# Altium schematic sheet Connectors.SchDoc(Connectors)
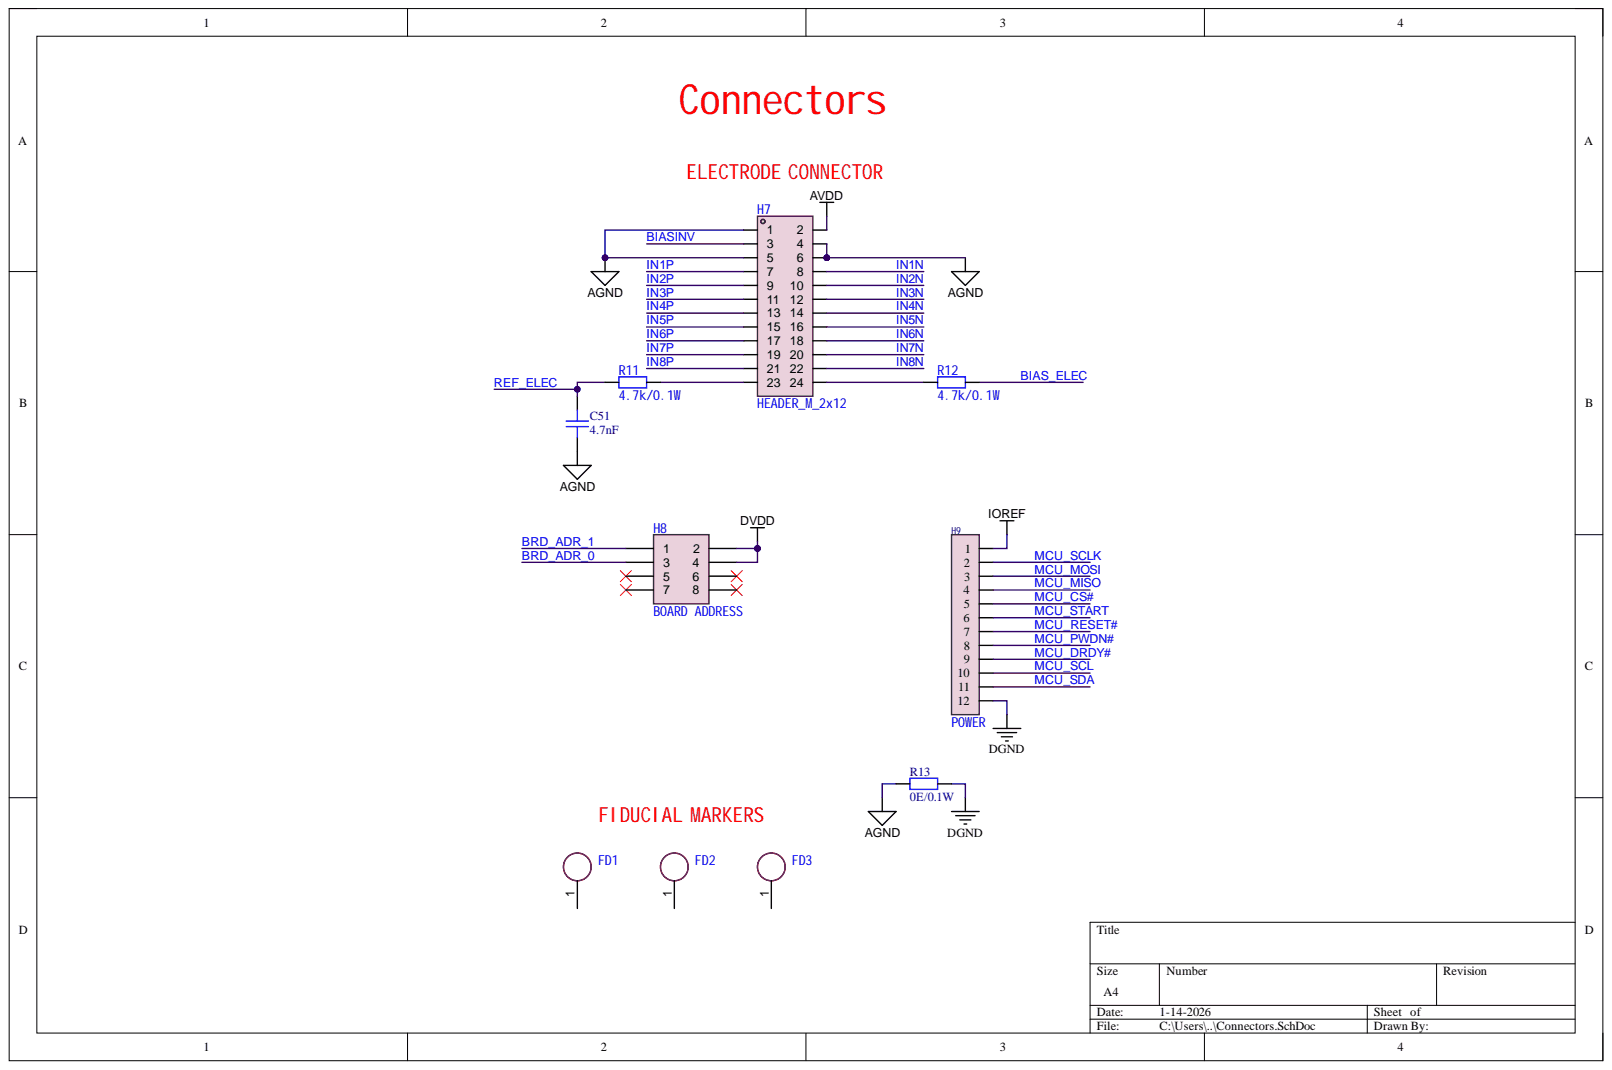
[diagram p0: Connectors.SchDoc]

## Parts
C51  value 4.7nF  [c0603]  (hidden comment: C0603C472K4RECAUTO)
FD1  FIDUCIAL MARKER  [FIDUCIAL MARKER]
FD2  FIDUCIAL MARKER  [FIDUCIAL MARKER]
FD3  FIDUCIAL MARKER  [FIDUCIAL MARKER]
H7  HEADER_M_2x12  [HEADER_M_2x12]
H8  BOARD ADDRESS  [HDR-TH_8P-P2.54-V-F-R2-C4-S2.54-1]
H9  POWER  [HDR-TH_12P-P2.54-V-F]
R11  4.7k  value 4.7k/0.1W  [R0603]
R12  4.7k  value 4.7k/0.1W  [R0603]
R13  value 0E/0.1W  [r0603]  (hidden comment: RC0603JR-070RL)

## Nets
AGND: C51.2 H7.1 H7.4 H7.5 H7.6 R13.1
AVDD: H7.2
DGND: H9.12 R13.2
DVDD: H8.2 H8.4
IOREF: H9.1
BIAS_ELEC: R12.2
BIASINV: H7.3
BRD_ADR_0: H8.3
BRD_ADR_1: H8.1
IN1N: H7.8
IN1P: H7.7
IN2N: H7.10
IN2P: H7.9
IN3N: H7.12
IN3P: H7.11
IN4N: H7.14
IN4P: H7.13
IN5N: H7.16
IN5P: H7.15
IN6N: H7.18
IN6P: H7.17
IN7N: H7.20
IN7P: H7.19
IN8N: H7.22
IN8P: H7.21
MCU_CS#: H9.5
MCU_DRDY#: H9.9
MCU_MISO: H9.4
MCU_MOSI: H9.3
MCU_PWDN#: H9.8
MCU_RESET#: H9.7
MCU_SCL: H9.10
MCU_SCLK: H9.2
MCU_SDA: H9.11
MCU_START: H9.6
REF_ELEC: C51.1 R11.1
NetH7_23: H7.23 R11.2
NetH7_24: H7.24 R12.1

Not connected: FD1 1; FD2 1; FD3 1; H8 5 6 7 8

## Library data of the parts
C51 = C0603C472K4RECAUTO: CAP CER 0603 4.7NF 16V X7R 10%; Component Kind: Standard; ComponentLink1Description: Product Link; ComponentLink1URL: https://www.digikey.in/en/products/detail/kemet/C0603C472K4RECAUTO/8640473; ComponentLink2Description: Datasheet Link; ComponentLink2URL: https://www.yageogroup.com/content/datasheet/asset/file/KEM_C1090_X7R_ESD; Library Name: US00001.SCHLIB
FD1-FD3 = FIDUCIAL MARKER: Add into BOM: yes; Component Kind: Standard; Convert to PCB: yes; Device: FIDUCIAL MARKER; Library Name: US00001.SCHLIB; Symbol: FIDUCIAL MARKER
H7 = HEADER_M_2x12: Add into BOM: yes; Component Kind: Standard; Convert to PCB: yes; Device: HEADER_M_2x12; Library Name: US00001.SCHLIB; Symbol: HEADER_M_2x12
H8 = HDR-F_2.54_2x4: Add into BOM: yes; Symbol: HDR-F-2.54_2x4; Component Kind: Standard; Convert to PCB: yes; Device: HDR-F_2.54_2x4; Library Name: US00001.SCHLIB; Supplier Footprint: Through
H9 = HDR-F_2.54_1x12P: Manufacturer: BOOMELE(博穆精密); Manufacturer Part: 2.54-1*8P母环保; Add into BOM: yes; Device: HDR-F_2.54_1x8P; Symbol: Header-Female-2.54_1x8; Component Kind: Standard; Convert to PCB: yes; JLCPCB Part Class: Extended Part; Library Name: US00001.SCHLIB; Supplier: LCSC; Supplier Footprint: Through; Supplier Part: C27438
R11 R12 = RC0603FR-134K7L: RES 4.7K OHM 1% 1/10W 0603; Add into BOM: yes; Device: Res_0603; Component Kind: Standard; ComponentLink1Description: Product link; ComponentLink1URL: https://www.digikey.in/en/products/detail/yageo/RC0603FR-134K7L/13694456?gclsrc=aw.ds&gad_source=1&gad_campaignid=23291916465&gbraid=0AAAAADrbLlj70qxStastrNTiTtbZYZxnf&gclid=CjwKCAiAjojLBhAlEiwAcjhrDih7UiqGp27o5fHELb6WSNmvTd6HGZDoEg_am2IlnZvnTOwhKgG11hoCu-QQAvD_BwE; Convert to PCB: yes; Library Name: US00001.SCHLIB; Supplier Footprint: 0603; Symbol: Res
R13 = RC0603JR-070RL: RES 0 OHM JUMPER 1/10W 0603; Component Kind: Standard; ComponentLink1Description: Product link; ComponentLink1URL: https://www.digikey.com/en/products/detail/yageo/RC0603JR-070RL/726675; Library Name: US00001.SCHLIB
